# Altium schematic sheet MotherBoard_JetsonSupport.SchDoc
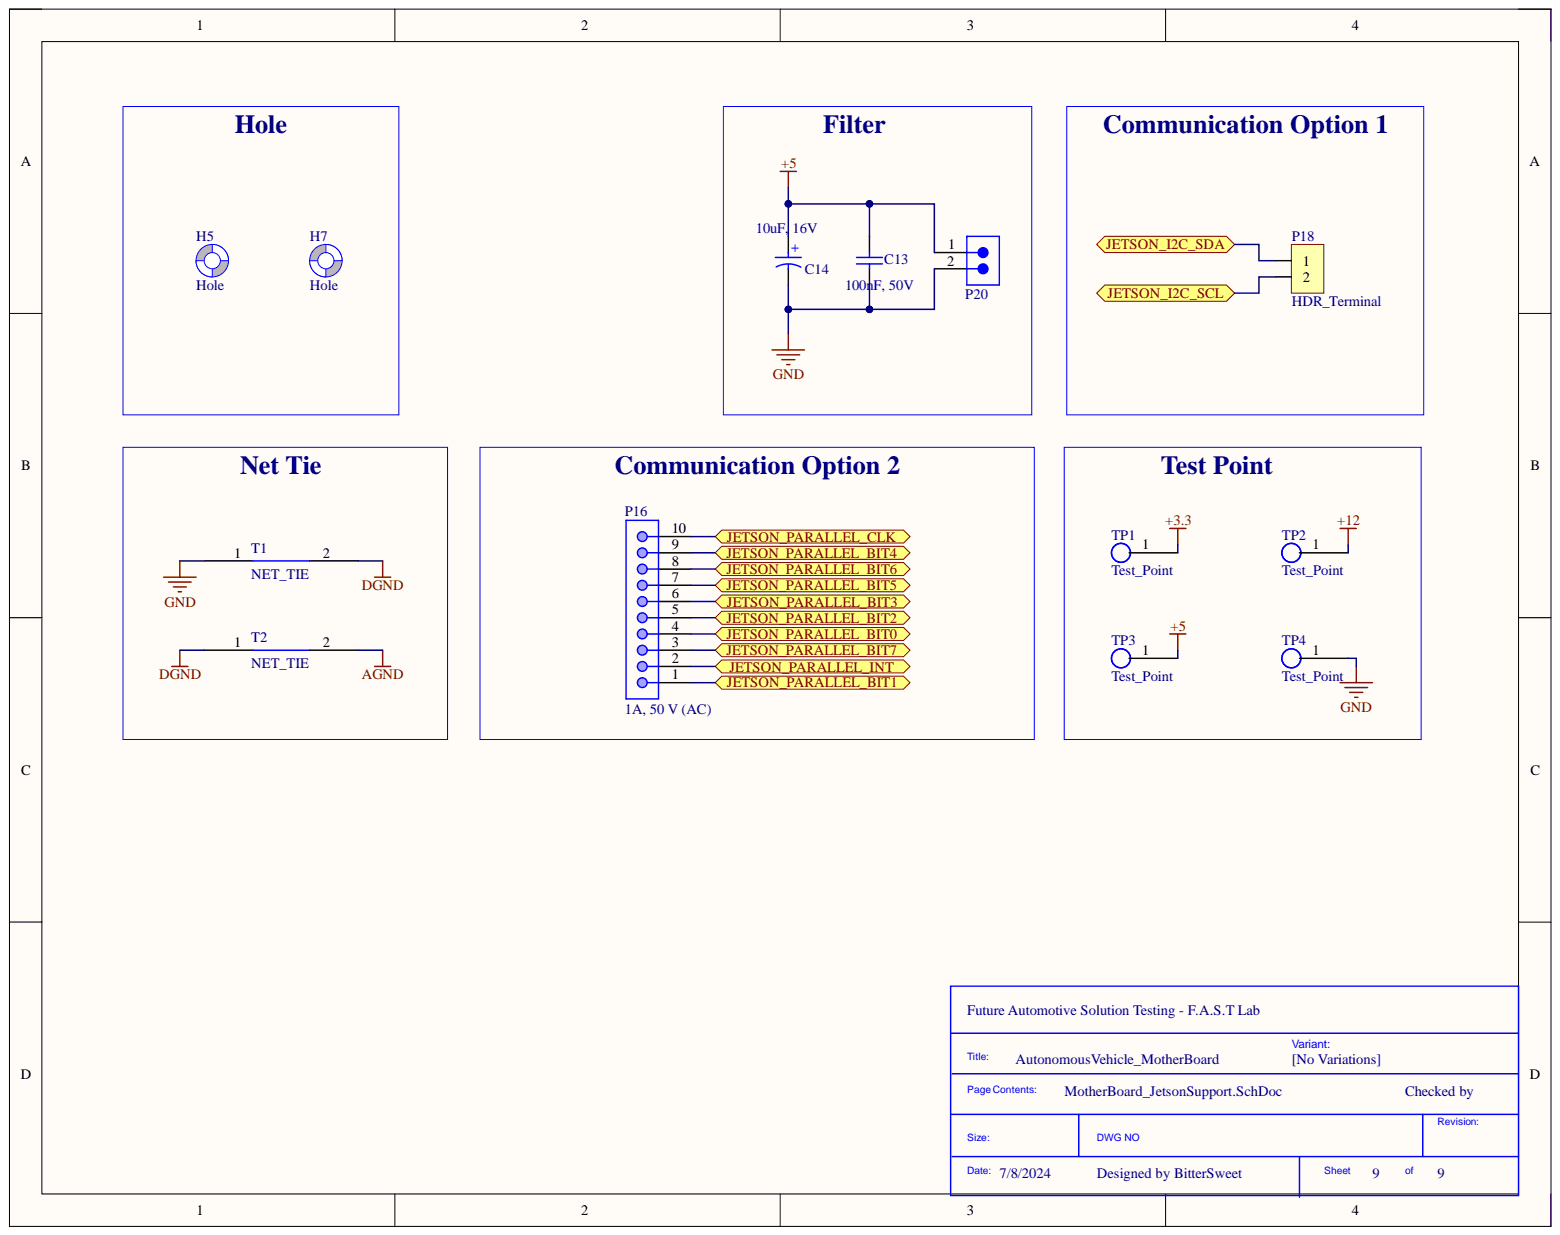
[diagram p0: MotherBoard_JetsonSupport.SchDoc]

## Parts
C13  100nF, 50V  [CAP_RADIAL_2.54]
C14  10uF, 16V  Rated Voltage (DC): 16V  [WCAP-ASLI_D4H5.5]
H5  Hole  [Hole_3.2mm]
H7  Hole  [Hole_3.2mm]
P16  1A, 50 V (AC)  [648110131822]
P18  HDR_Terminal  [HDR_Header_2.54]
P20  10A, 300V  [691321300002]  (value not drawn)
T1  NET_TIE  [NET_TIE_2.0mm]
T2  NET_TIE  [NET_TIE_0.5MM]
TP1  Test_Point  [TP_1_5mm_SMD]
TP2  Test_Point  [TP_1_5mm_SMD]
TP3  Test_Point  [TP_1_5mm_SMD]
TP4  Test_Point  [TP_1_5mm_SMD]

## Ports
JETSON_I2C_SCL, JETSON_I2C_SDA, JETSON_PARALLEL_BIT0, JETSON_PARALLEL_BIT1, JETSON_PARALLEL_BIT2, JETSON_PARALLEL_BIT3, JETSON_PARALLEL_BIT4, JETSON_PARALLEL_BIT5, JETSON_PARALLEL_BIT6, JETSON_PARALLEL_BIT7, JETSON_PARALLEL_CLK, JETSON_PARALLEL_INT

## Nets
+3.3: TP1.1
+5: C13.1 C14.1 P20.1 TP3.1
+12: TP2.1
AGND: T2.2
DGND: T1.2 T2.1
GND: C13.2 C14.2 P20.2 T1.1 TP4.1
JETSON_I2C_SCL: P18.2 ; port JETSON_I2C_SCL
JETSON_I2C_SDA: P18.1 ; port JETSON_I2C_SDA
JETSON_PARALLEL_BIT0: P16.4 ; port JETSON_PARALLEL_BIT0
JETSON_PARALLEL_BIT1: P16.1 ; port JETSON_PARALLEL_BIT1
JETSON_PARALLEL_BIT2: P16.5 ; port JETSON_PARALLEL_BIT2
JETSON_PARALLEL_BIT3: P16.6 ; port JETSON_PARALLEL_BIT3
JETSON_PARALLEL_BIT4: P16.9 ; port JETSON_PARALLEL_BIT4
JETSON_PARALLEL_BIT5: P16.7 ; port JETSON_PARALLEL_BIT5
JETSON_PARALLEL_BIT6: P16.8 ; port JETSON_PARALLEL_BIT6
JETSON_PARALLEL_BIT7: P16.3 ; port JETSON_PARALLEL_BIT7
JETSON_PARALLEL_CLK: P16.10 ; port JETSON_PARALLEL_CLK
JETSON_PARALLEL_INT: P16.2 ; port JETSON_PARALLEL_INT

Not connected: H5 1; H7 1

## Library data of the parts
C13 = Capacitor: Component Kind: Standard; Description: Capacitor; URL: https://www.thegioiic.com/tu-gom-tron-100nf-0-1uf-50v; Library Name: AutonomousVehicle_Lib.IntLib
C14 = 865080340001: V-Chip, D4 x H5.5mm, 10uF, 16V; Manufacturer: Wurth Elektronik; Capacitance Tolerance: +/-20%; Dissipation Factor: 16%; Endurance: 2000h; Leakage Current: 3uA; Ripple Current: 80mA; Capacitance: 10uF; Category: Aluminum Electrolytic Capacitors; Component Kind: Standard; ComponentLink2Description: Datasheet Link; ComponentLink2URL: https://www.we-online.com/redexpert/spec/865080340001?ad; Diameter: 4mm; Height: 5.5mm; Manufacturer Part Number: N/A; Mount: Surface Mount; Operating Temperature Max: 105°C; Operating Temperature Min: -55°C; Packaging: Tape and Reel; Supplier 1 Name: Mouser; Supplier 1 SN: 710-865080340001; Supplier 1 URL: https://www.mouser.com/ProductDetail/Wurth-Elektronik/865080340001?qs=0KOYDY2FL2%252BaqRFD837lAw%3D%3D; Supplier 2 Name: Digi-Key; Supplier 2 SN: N/A; Supplier 2 URL: N/A; Supplier 3 Name: thegioiic; Supplier 3 SN: N/A; Supplier 3 URL: https://www.thegioiic.com/tu-nhom-smd-10uf-16v-4x5-4mm; Value: 10uF, 16V; Library Name: Altium_Alutinum_Cap.IntLib
P16 = 648110131822: WR-WTB SMT Male Horizontal Shrouded Header, pitch 1.5mm, 10p; Contact Resistance: 20mOhm; Pin Number: 10; Withstanding Voltage: 500VAC; Working Voltage: 50VAC; Component Kind: Standard; ComponentLink1Description: Manufacturer; ComponentLink1URL: http://www.we-online.de; ComponentLink2Description: Datasheet; ComponentLink2URL: https://www.we-online.com/catalog/datasheet/648110131822.pdf; ComponentLink3Description: Global Supply; ComponentLink3URL: https://www.we-online.com/en/components/products/WTB_1_50_SMT_MALE_HORIZONTAL_SHROUDED_HEADER_6481XX131822?sq=648110131822#648110131822; ComponentLink4Description: Global Supply; ComponentLink4URL: https://www.mouser.vn/ProductDetail/Wurth-Elektronik/648110131822?qs=3RJ0PdSuLVmrqG7i4WUbBw%3D%3D; Gender: Male; Library Name: Altium_PCB_Connector.IntLib; Mounting Technology: SMT; Operating Temperature: -25 to +85degC; Packaging: Tape and Reel; Part Number: 648110131822; Pitch: 1.5mm; Published Date: January 2020; Rated Current: 1A; Supplier 1 Name: Mouser; Supplier 1 SN: N/A; Supplier 1 URL: N/A; Supplier 2 Name: Digi-Key; Supplier 2 SN: N/A; Supplier 2 URL: N/A; Supplier 3 Name: thegioiic; Supplier 3 SN: N/A; Supplier 3 URL: N/A; Type: Horizontal; Value: 1A, 50 V (AC); Publisher: Wurth Elektronik
P18 = HDR_Terminal: HDR_Terminal 2 pin; Component Kind: Standard; ComponentLink1Description: URL; ComponentLink1URL: https://www.thegioiic.com/kf128-2-v-domino-2-chan-thang-3-5mm-300v-10a-han-pcb; Supplier: ThegioiIC; Library Name: AutonomousVehicle_Lib.IntLib
P20 = 691321300002: WR-TBL Series 321 Vertical PCB Header, THT, pitch 3.81mm, 2p; Manufacturer: Wurth Elektronik; Modularity: No; Application: Pluggable; Category: Terminal Blocks; Component Kind: Standard; ComponentLink1Description: Datasheet Link; ComponentLink1URL: https://www.we-online.com/redexpert/spec/691321300002?ad; ComponentLink2Description: Supplier 1 URL; ComponentLink2URL: N/A; ComponentLink3Description: Supplier 2 URL; ComponentLink3URL: N/A; ComponentLink4Description: Supplier 3 URL; ComponentLink4URL: https://www.thegioiic.com/ul-3-81-2-v-terminal-block-plug-in-han-pcb-2-chan-thang-3-81mm-300v-10a-ulo; Library Name: Altium_Terminal_Block.IntLib; Manufacturer Part Number: 691321300002; Match Code: WR-TBL; Mount: Through Hole; Number of Pins: 2; Number of Rows: 1; Operating Temperature Max: 105°C; Operating Temperature Min: -40°C; Orientation: Vertical; Packaging: Box; Pitch: 3.81mm; Rated Current: 10A; Supplier 1 Name: Mouser; Supplier 1 SN: N/A; Supplier 1 URL: N/A; Supplier 2 Name: Digi-Key; Supplier 2 SN: N/A; Supplier 2 URL: N/A; Supplier 3 Name: thegioiic; Supplier 3 SN: N/A; Supplier 3 URL: https://www.thegioiic.com/ul-3-81-2-v-terminal-block-plug-in-han-pcb-2-chan-thang-3-81mm-300v-10a-ulo; Value: 10A, 300V; Working Voltage: 300V
T1 T2 = NET_TIE: Straight Net Tie; Component Kind: Standard; Library Name: AutonomousVehicle_Lib.IntLib
H5 H7 = Hole: Component Kind: Standard; Description: Hole; Library Name: AutonomousVehicle_Lib.IntLib
TP1-TP4 = Test_Point: Component Kind: Standard; Description: Test_Point; Library Name: AutonomousVehicle_Lib.IntLib
